# Altium schematic sheet Schematic.SchDoc (continued)
## Library data of the parts (continued)
TR1 = B66417G0000X149: Ferrite Cores & Accessories EFD20/10/7N49OL; Manufacturer: TDK EPCOS; Category: Uncategorized; Component Kind: Standard; ComponentLink1Description: https://datasheet.ciiva.com/12390/efd-20-10-7-70808-12390500.pdf?src-supplier=Mouser; ComponentLink1URL: https://datasheet.ciiva.com/12390/efd-20-10-7-70808-12390500.pdf?src-supplier=Mouser; Library Name: Schlib2.SchLib; Manufacturer Part Number: B66417G0000X149; Pricing: 1=0.72, 10=0.644, 50=0.453, 100=0.42, 1000=0.42, 10000=0.419 (USD); RoHS: Yes; Stock: 2950; Supplier: Mouser; Supplier Part Number: 871-B66417G0000X149
U1 = TBSA30M-TP: Bridge Rectifiers BRIDGE RECTIFIERS 1000V 3A, TBSG; Manufacturer: MCC; Category: LDO; Component Kind: Standard; Library Name: Schlib2.SchLib; Manufacturer Part Number: TBSA30M-TP; Pricing: 1=0.4, 10=0.347, 50=0.347, 100=0.241, 1000=0.153, 10000=0.12 (USD); RoHS: No; Stock: 2486; Supplier: Mouser; Supplier Part Number: 833-TBSA30M-TP
U2 = VO617A-9X017T: Transistor Output Optocoupler, 1-Element, 5300V Isolation; Manufacturer: Vishay; Coll-emtr Bkdn Voltage-Min: 80V; Current Transfer Ratio-Nom: 200%; Dark Current-Max: 100nA; Forward Current: 60mA; Isolation Voltage-Max: 5.3kV; Number of Elements: 1; Category: Optocouplers; Component Kind: Standard; ComponentLink1Description: https://datasheet.ciiva.com:443/pdfs/VipMasterIC/IC/VISH/VISH-S-A0004680336/VISH-S-A0004680336-1.pdf?src-supplier=IHS+Markit; ComponentLink1URL: https://datasheet.ciiva.com:443/pdfs/VipMasterIC/IC/VISH/VISH-S-A0004680336/VISH-S-A0004680336-1.pdf?src-supplier=IHS+Markit; JESD-609 Code: e3; Library Name: Schlib2.SchLib; Manufacturer Part Number: VO617A-9X017T; Max Operating Temperature: 110°C; Min Operating Temperature: -55°C; Optoelectronic Device Type: TRANSISTOR OUTPUT OPTOCOUPLER; Pricing: 1=0.43, 10=0.263, 100=0.151 (USD); RoHS: Yes; RoHS Compliant: Yes; Stock: 79924; Supplier: Digikey; Supplier Part Number: 751-VO617A-9X017TCT-ND; Terminal Finish: Tin
U3 = TL431ID: Three Terminal Voltage Reference, 1 Output, 2.495V, Trim/Adjustable, BIPolar, PDSO8; Manufacturer: Texas Instruments; Channels: 1; Max Output current: 100mA; Max Output Voltage: 2.55V; Max Supply Voltage: 36V; Min Output Voltage: 2.44V; Package Body Material: Plastic; Package Equivalence Code: SOP8,.25; Qualification Status: Not Qualified; Temp Coef of Voltage-Max: 109.018ppm/°C; Trim/Adjustable Output: Yes; Case/Package: SOP; Category: Voltage References; Component Kind: Standard; ComponentLink1Description: https://www.ti.com:443/lit/ds/symlink/tl431.pdf?src-supplier=IHS+Markit; ComponentLink1URL: https://www.ti.com:443/lit/ds/symlink/tl431.pdf?src-supplier=IHS+Markit; ComponentLink2Description: https://datasheet.ciiva.com/3235/tl432b-3235628.pdf?src-supplier=Avnet; ComponentLink2URL: https://datasheet.ciiva.com/3235/tl432b-3235628.pdf?src-supplier=Avnet; ComponentLink3Description: https://datasheet.ciiva.com/2011/tl431a-2011335.pdf?src-supplier=Digikey; ComponentLink3URL: https://datasheet.ciiva.com/2011/tl431a-2011335.pdf?src-supplier=Digikey; Height - Seated (Max): 1.75mm; JESD-30 Code: R-PDSO-G8; JESD-609 Code: e4; Length: 4.9mm; Library Name: Schlib2.SchLib; Manufacturer Part Number: TL431ID; Max Operating Temperature: 85°C; Min Operating Temperature: -40°C; Min Supply Voltage: 2.495V; Moisture Sensitivity Level: 1; Nominal Output Voltage: 2.495V; Number of Outputs: 1; Package Shape: Rectangular; Package Style: SMALL OUTLINEMeter; Peak Reflow Temperature (Cel): 260°C; Pins: 8; Pricing: 1=0.7, 10=0.499, 50=0.499, 100=0.362, 1000=0.357, 10000=0.342 (USD); Reach SVHC Compliant: Yes; RoHS: Yes; RoHS Compliant: Yes; Stock: 44786; Supplier: Mouser; Supplier Part Number: 595-TL431ID; Supply Current-Max (Isup): 100mA; Surface Mount: Yes; Technology: BIPOLAR; Temperature Grade: INDUSTRIAL; Terminal Finish: Nickel; Terminal Form: Gull Wing; Terminal Pitch: 1.27mm; Terminal Position: DUAL; Throw Configuration: THREE TERMINAL VOLTAGE REFERENCE; Width: 3.9mm
U4 = UC3844D8: Switching Controller, Current-mode, 1A, 500kHz Switching Freq-Max, BICMOS, PDSO8; Manufacturer: Texas Instruments; Channels: 1; Control Technique: PULSE WIDTH MODULATION; Max Supply Voltage: 25V; Min Input Voltage: 11.5V; Nominal Output Voltage: 5V; Nominal Supply Voltage: 15V; Package Body Material: Plastic; Package Equivalence Code: SOP8,.25; Qualification Status: Not Qualified; Switcher Configuration: SINGLE; Case/Package: SOP; Category: Voltage Regulators - Switching; Component Kind: Standard; ComponentLink1Description: https://www.ti.com:443/lit/ds/symlink/uc1842-sp.pdf?src-supplier=IHS+Markit; ComponentLink1URL: https://www.ti.com:443/lit/ds/symlink/uc1842-sp.pdf?src-supplier=IHS+Markit; ComponentLink2Description: https://datasheet.ciiva.com/15106/getdatasheetpartid-294880-15106876.pdf?src-supplier=Verical; ComponentLink2URL: https://datasheet.ciiva.com/15106/getdatasheetpartid-294880-15106876.pdf?src-supplier=Verical; ComponentLink3Description: https://datasheet.ciiva.com/14264/slus223c-14264797.pdf?src-supplier=Avnet; ComponentLink3URL: https://datasheet.ciiva.com/14264/slus223c-14264797.pdf?src-supplier=Avnet; ComponentLink4Description: https://datasheet.ciiva.com/2020/uc3842-2020448.pdf?src-supplier=Digikey; ComponentLink4URL: https://datasheet.ciiva.com/2020/uc3842-2020448.pdf?src-supplier=Digikey; Control Mode: CURRENT-MODE; Frequency: 500kHz; Height - Seated (Max): 1.75mm; JESD-30 Code: R-PDSO-G8; JESD-609 Code: e4; Length: 4.9mm; Library Name: Schlib2.SchLib; Manufacturer Part Number: UC3844D8; Max Operating Temperature: 70°C; Max Output current: 1A; Min Operating Temperature: 0°C; Moisture Sensitivity Level: 1; Package Shape: Rectangular; Package Style: SMALL OUTLINEMeter; Peak Reflow Temperature (Cel): 260°C; Pins: 8; Pricing: 1=2, 10=2, 50=2, 100=1.69, 1000=0.92, 10000=0.712 (USD); Reach SVHC Compliant: Yes; RoHS: Yes; RoHS Compliant: Yes; Stock: 373; Supplier: Mouser; Supplier Part Number: 595-UC3844D8; Surface Mount: Yes; Technology: BICMOS; Temperature Grade: COMMERCIAL; Terminal Finish: Nickel; Terminal Form: Gull Wing; Terminal Pitch: 1.27mm; Terminal Position: DUAL; Throw Configuration: SWITCHING CONTROLLER; Width: 3.9mm
